# Altium schematic sheet MainBoard.SchDoc
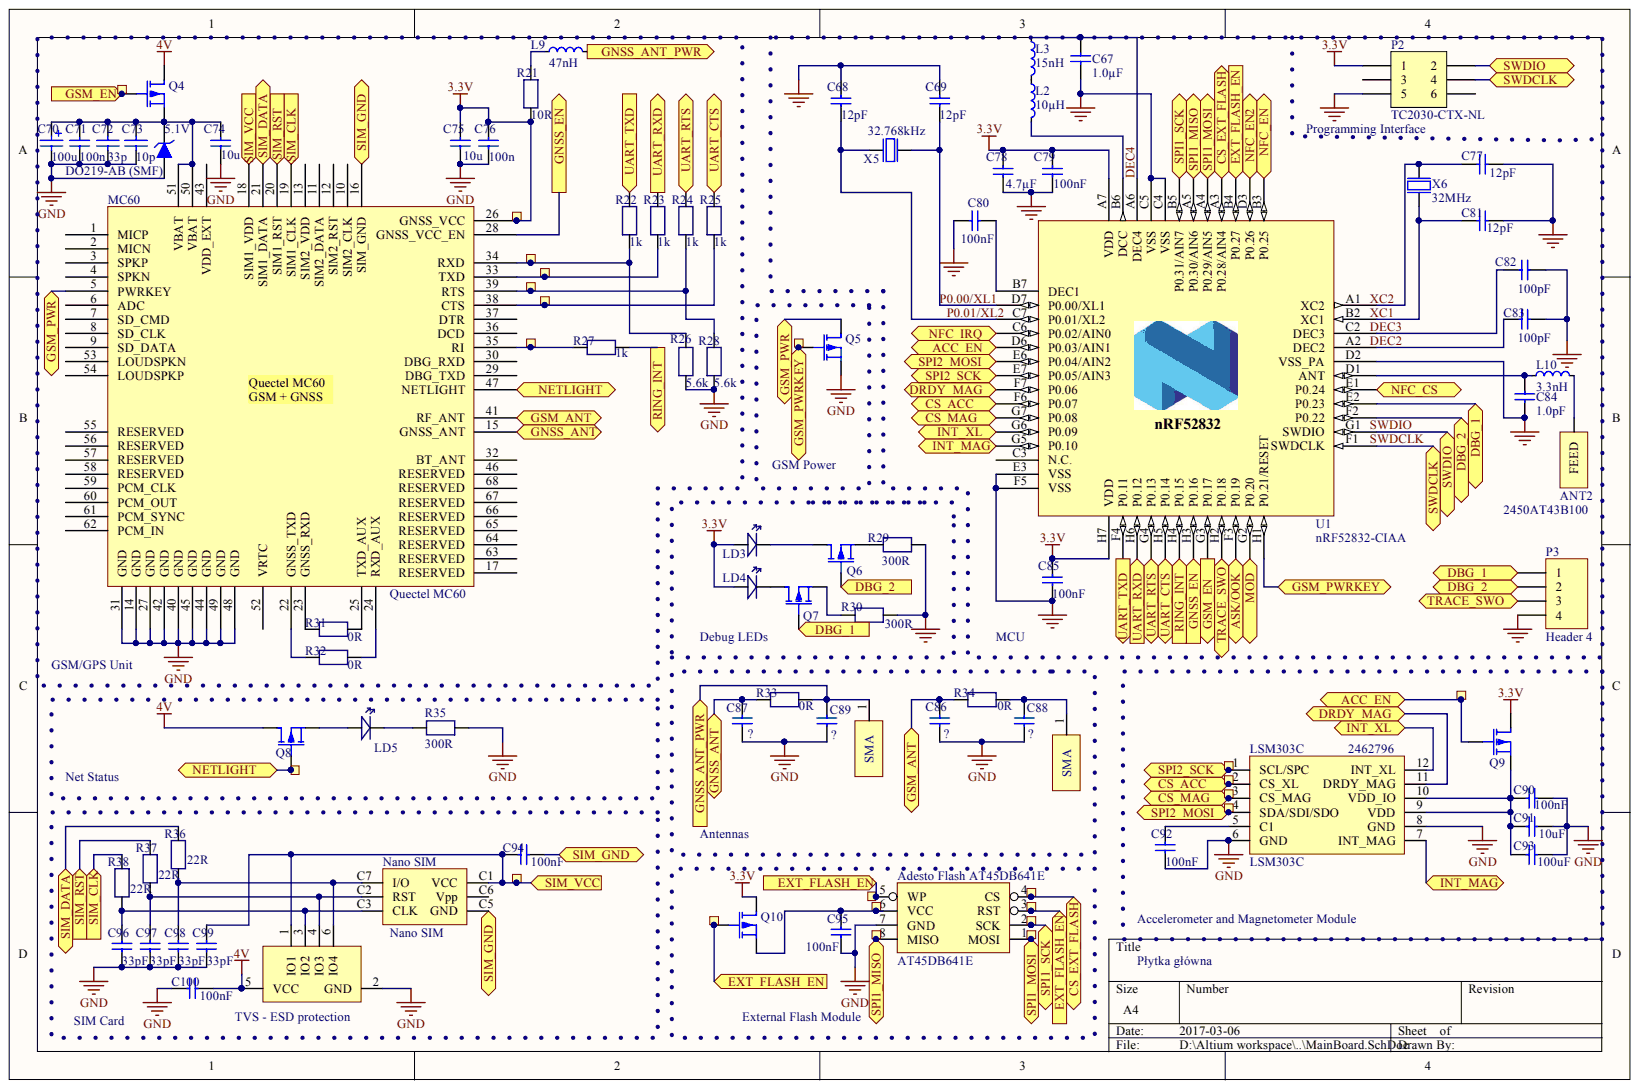
[diagram p0: MainBoard.SchDoc]

## Parts
5  Ø1.0mm,SMD  [TP_SMD_Ø1.0mm]  (value not drawn)  (no BOM)
5.1V  DO219-AB (SMF)  [DO219-AB]
6  Ø1.0mm,SMD  [TP_SMD_Ø1.0mm]  (value not drawn)  (no BOM)
7  Ø1.0mm,SMD  [TP_SMD_Ø1.0mm]  (value not drawn)  (no BOM)
8  Ø1.0mm,SMD  [TP_SMD_Ø1.0mm]  (value not drawn)  (no BOM)
9  Ø1.0mm,SMD  [TP_SMD_Ø1.0mm]  (value not drawn)  (no BOM)
10  Ø1.0mm,SMD  [TP_SMD_Ø1.0mm]  (value not drawn)  (no BOM)
11  Ø1.0mm,SMD  [TP_SMD_Ø1.0mm]  (value not drawn)  (no BOM)
12  Ø1.0mm,SMD  [TP_SMD_Ø1.0mm]  (value not drawn)  (no BOM)
13  Ø1.0mm,SMD  [TP_SMD_Ø1.0mm]  (value not drawn)  (no BOM)
14  Ø1.0mm,SMD  [TP_SMD_Ø1.0mm]  (value not drawn)  (no BOM)
15  Ø1.0mm,SMD  [TP_SMD_Ø1.0mm]  (value not drawn)  (no BOM)
16  Ø1.0mm,SMD  [TP_SMD_Ø1.0mm]  (value not drawn)  (no BOM)
17  Ø1.0mm,SMD  [TP_SMD_Ø1.0mm]  (value not drawn)  (no BOM)
18  Ø1.0mm,SMD  [TP_SMD_Ø1.0mm]  (value not drawn)  (no BOM)
19  Ø1.0mm,SMD  [TP_SMD_Ø1.0mm]  (value not drawn)  (no BOM)
20  Ø1.0mm,SMD  [TP_SMD_Ø1.0mm]  (value not drawn)  (no BOM)
21  Ø1.0mm,SMD  [TP_SMD_Ø1.0mm]  (value not drawn)  (no BOM)
22  Ø1.0mm,SMD  [TP_SMD_Ø1.0mm]  (value not drawn)  (no BOM)
23  Ø1.0mm,SMD  [TP_SMD_Ø1.0mm]  (value not drawn)  (no BOM)
24  Ø1.0mm,SMD  [TP_SMD_Ø1.0mm]  (value not drawn)  (no BOM)
25  Ø1.0mm,SMD  [TP_SMD_Ø1.0mm]  (value not drawn)  (no BOM)
26  Ø1.0mm,SMD  [TP_SMD_Ø1.0mm]  (value not drawn)  (no BOM)
27  Ø1.0mm,SMD  [TP_SMD_Ø1.0mm]  (value not drawn)  (no BOM)
Adesto_Flash_AT45DB641E  AT45DB641E  [UDFN 5x6mm 8pin]
ANT2  2450AT43B100  [2450AT43B100]
C67  1.0µF  [CAPC1005X04L]
C68  12pF  [CAPC0603X03L]
C69  12pF  [CAPC0603X03L]
C70  value 100u  [B]
C71  100n  [CAPC1005X04L]
C72  value 33p  [1608[0603]]
C73  value 10p  [1608[0603]]
C74  10u  [CAPC1608X06L]
C75  10u  [CAPC1608X06L]
C76  100n  [CAPC1005X04L]
C77  12pF  [CAPC0603X03L]
C78  4.7µF  [CAPC1608X06L]
C79  100nF  [CAPC1005X04L]
C80  100nF  [CAPC1005X04L]
C81  12pF  [CAPC0603X03L]
C82  100pF  [CAPC0603X03L]
C83  100pF  [CAPC0603X03L]
C84  1.0pF  [CAPC0603X03L]
C85  100nF  [CAPC1005X04L]
C86  ?  [CAPC1005X04L]
C87  ?  [CAPC1005X04L]
C88  ?  [CAPC1005X04L]
C89  ?  [CAPC1005X04L]
C90  100nF  [CAPC1005X04L]
C91  10uF  [CAPC1005X04L]
C92  100nF  [CAPC1005X04L]
C93  100uF  [CAPC1005X04L]
C94  100nF  [CAPC1005X04L]
C95  100nF  [CAPC1005X04L]
C96  33pF  [CAPC1005X04L]
C97  33pF  [CAPC1005X04L]
C98  33pF  [CAPC1005X04L]
C99  33pF  [CAPC1005X04L]
C100  100nF  [CAPC1005X04L]
L2  10µH  [INDC1608X06L]
L3  15nH  [INDC1005X04L]
L9  47nH  [INDC1005X04L]
L10  3.3nH  [INDC0603X03L]
LD3  0603_LED  [LED_0603]  (value not drawn)
LD4  0603_LED  [LED_0603]  (value not drawn)
LD5  0603_LED  [LED_0603]  (value not drawn)
LSM303C  LSM303C  Supplier Part Number 1: 2462796  [LGA-12]
MC60  Quectel MC60  [Quactel MC60 Footprint]
Nano_SIM  Nano SIM  [NANO_Sim_Holder]
P2  TC2030-CTX-NL  [TC2030-IDC-NLFP]  (no BOM)
P3  Header 4  [HDR1X4]
Q4  RZE002P02TLTR-ND  [SOT-416]  (value not drawn)
Q5  RZE002P02TLTR-ND  [SOT-416]  (value not drawn)
Q6  RZE002P02TLTR-ND  [SOT-416]  (value not drawn)
Q7  RZE002P02TLTR-ND  [SOT-416]  (value not drawn)
Q8  RZE002P02TLTR-ND  [SOT-416]  (value not drawn)
Q9  RZE002P02TLTR-ND  [SOT-416]  (value not drawn)
Q10  RZE002P02TLTR-ND  [SOT-416]  (value not drawn)
R21  value 10R  [RES_0402]  (hidden comment: RES_0402_1k)
R22  value 1k  [RES_0402]  (hidden comment: RES_0402_1k)
R23  value 1k  [RES_0402]  (hidden comment: RES_0402_1k)
R24  value 1k  [RES_0402]  (hidden comment: RES_0402_1k)
R25  value 1k  [RES_0402]  (hidden comment: RES_0402_1k)
R26  value 5.6k  [RES_0402]  (hidden comment: RES_0402_1k)
R27  value 1k  [RES_0402]  (hidden comment: RES_0402_1k)
R28  value 5.6k  [RES_0402]  (hidden comment: RES_0402_1k)
R29  value 300R  [RES_0402]  (hidden comment: RES_0402_1k)
R30  value 300R  [RES_0402]  (hidden comment: RES_0402_1k)
R31  value 0R  [RES_0402]  (hidden comment: RES_0402_1k)
R32  value 0R  [RES_0402]  (hidden comment: RES_0402_1k)
R33  value 0R  [RES_0402]  (hidden comment: RES_0402_1k)
R34  value 0R  [RES_0402]  (hidden comment: RES_0402_1k)
R35  value 300R  [RES_0402]  (hidden comment: RES_0402_1k)
R36  value 22R  [RES_0402]  (hidden comment: RES_0402_1k)
R37  value 22R  [RES_0402]  (hidden comment: RES_0402_1k)
R38  value 22R  [RES_0402]  (hidden comment: RES_0402_1k)
SMA_connector  GSM_SMA  [SMA_Footprint]  (value not drawn)
SMA_connector  GNSS_SMA  [SMA_Footprint]  (value not drawn)
U1  nRF52832-CIAA  [BGA50C40P7X8_295X322X50]
X5  32.768kHz  [XTAL_2012]
X6  32MHz  [BT-XTAL_2016]
  TVS - ESD protection  [SO-G6/P.95]

## Ports
ACC_EN, ASK/OOK, CS_ACC, CS_EXT_FLASH, CS_MAG, DBG_1, DBG_2, DRDY_MAG, EXT_FLASH_EN, GNSS_ANT, GNSS_ANT_PWR, GNSS_EN, GSM_ANT, GSM_EN, GSM_PWR, GSM_PWRKEY, INT_MAG, INT_XL, MOD, NETLIGHT, NFC_CS, NFC_EN, NFC_EN2, NFC_IRQ, RING_INT, SIM_CLK, SIM_DATA, SIM_GND, SIM_RST, SIM_VCC, SPI1_MISO, SPI1_MOSI, SPI1_SCK, SPI2_MOSI, SPI2_SCK, SWDCLK, SWDIO, TRACE_SWO, UART_CTS, UART_RTS, UART_RXD, UART_TXD

## Nets
3.3V: 6.1 C75.1 C76.2 C78.1 C79.2 C85.2 LD3.1 LD4.1 MC60.26(GNSS_VCC) P2.1 Q9.3 Q10.3 R21.2 U1.A7(VDD) U1.H7(VDD)
4V: C100.2 Q4.3 Q8.3 .5(VCC)
GND: 5.1V.1 Adesto_Flash_AT45DB641E.7(GND) C67.1 C68.2 C69.2 C70.2 C71.1 C72.1 C73.1 C74.2 C75.2 C76.1 C77.1 C78.2 C79.1 C80.2 C81.1 C82.2 C83.1 C84.1 C85.1 C86.2 C87.2 C88.2 C89.2 C90.2 C91.1 C92.1 C93.1 C95.1 C96.2 C97.2 C98.1 C99.2 C100.1 LSM303C.6(GND) LSM303C.8(GND) MC60.14(GND) MC60.27(GND) MC60.31(GND) MC60.40(GND) MC60.42(GND) MC60.44(GND) MC60.45(GND) MC60.48(GND) MC60.49(GND) P2.5 P3.4 Q5.2 R26.1 R28.1 R29.1 R30.1 R35.1 U1.C4(VSS) U1.C5(VSS) U1.D2(VSS_PA) U1.E3(VSS) U1.F5(VSS) X6.2 X6.4 .2(GND)
Net_3: C96.1 Nano_SIM.C3(CLK) R38.2 .3(IO2)
Net_4: C97.1 Nano_SIM.C2(RST) R37.2 .4(IO3)
Net_6: C98.2 Nano_SIM.C7(I/O) R36.2 .6(IO4)
ACC_EN: 13.1 Q9.1 U1.D6(P0.03/AIN1) ; port ACC_EN
ASK/OOK: U1.F3(P0.19) ; port ASK/OOK
CS_ACC: 16.1 LSM303C.2(CS_XL) U1.F6(P0.07) ; port CS_ACC
CS_EXT_FLASH: 20.1 Adesto_Flash_AT45DB641E.4(CS) U1.A3(P0.28/AIN4) ; port CS_EXT_FLASH
CS_MAG: 17.1 LSM303C.3(CS_MAG) U1.G7(P0.08) ; port CS_MAG
DBG_1: P3.1 Q7.1 U1.E2(P0.23) ; port DBG_1
DBG_2: P3.2 Q6.1 U1.F2(P0.22) ; port DBG_2
DEC4: C67.2 L3.1 U1.A6(DEC4)
DRDY_MAG: LSM303C.11(DRDY_MAG) U1.F7(P0.06) ; port DRDY_MAG
EXT_FLASH_EN: 21.1 23.1 24.1 Adesto_Flash_AT45DB641E.3(RST) Adesto_Flash_AT45DB641E.5(WP) Q10.1 U1.B4(P0.27) ; port EXT_FLASH_EN
GNSS_ANT: C87.1 MC60.15(GNSS_ANT) R33.1 ; port GNSS_ANT
GNSS_ANT_PWR: C89.1 L9.2 R33.2 SMA_connector.1 ; port GNSS_ANT_PWR
GNSS_EN: MC60.28(GNSS_VCC_EN) U1.H3(P0.16) ; port GNSS_EN
GSM_ANT: C86.1 MC60.41(RF_ANT) R34.2 ; port GSM_ANT
GSM_EN: 5.1 Q4.1 U1.G3(P0.17) ; port GSM_EN
GSM_PWR: MC60.5(PWRKEY) Q5.3 ; port GSM_PWR
GSM_PWRKEY: 12.1 Q5.1 U1.H1(P0.21/RESET) ; port GSM_PWRKEY
INT_MAG: LSM303C.7(INT_MAG) U1.G5(P0.10) ; port INT_MAG
INT_XL: LSM303C.12(INT_XL) U1.G6(P0.09) ; port INT_XL
MOD: U1.G2(P0.20) ; port MOD
Net_1: 19.1 C94.1 C99.1 MC60.18(SIM1_VDD) Nano_SIM.C1(VCC) .1(IO1) ; port SIM_VCC
NETLIGHT: 15.1 MC60.47(NETLIGHT) Q8.1 ; port NETLIGHT
NFC_CS: U1.E1(P0.24) ; port NFC_CS
NFC_EN: U1.B3(P0.25) ; port NFC_EN
NFC_EN2: U1.D3(P0.26) ; port NFC_EN2
NFC_IRQ: U1.C6(P0.02/AIN0) ; port NFC_IRQ
P0.00/XL1: C69.1 U1.D7(P0.00/XL1) X5.2
P0.01/XL2: C68.1 U1.C7(P0.01/XL2) X5.1
RING_INT: R27.1 U1.H4(P0.15) ; port RING_INT
SIM_CLK: MC60.19(SIM1_CLK) R38.1 ; port SIM_CLK
SIM_DATA: MC60.21(SIM1_DATA) R36.1 ; port SIM_DATA
SIM_GND: C94.2 MC60.16(SIM_GND) Nano_SIM.C5(GND) ; port SIM_GND
SIM_RST: MC60.20(SIM1_RST) R37.1 ; port SIM_RST
SPI1_MISO: 26.1 Adesto_Flash_AT45DB641E.8(MISO) U1.A5(P0.30/AIN6) ; port SPI1_MISO
SPI1_MOSI: 27.1 Adesto_Flash_AT45DB641E.1(MOSI) U1.A4(P0.29/AIN5) ; port SPI1_MOSI
SPI1_SCK: 25.1 Adesto_Flash_AT45DB641E.2(SCK) U1.B5(P0.31/AIN7) ; port SPI1_SCK
SPI2_MOSI: 18.1 LSM303C.4(SDA/SDI/SDO) U1.E6(P0.04/AIN2) ; port SPI2_MOSI
SPI2_SCK: 14.1 LSM303C.1(SCL/SPC) U1.E7(P0.05/AIN3) ; port SPI2_SCK
SWDCLK: P2.4 U1.F1(SWDCLK) ; port SWDCLK
SWDIO: P2.2 U1.G1(SWDIO) ; port SWDIO
TRACE_SWO: P3.3 U1.H2(P0.18) ; port TRACE_SWO
UART_CTS: R25.2 U1.H5(P0.14) ; port UART_CTS
UART_RTS: R24.2 U1.G4(P0.13) ; port UART_RTS
UART_RXD: R23.2 U1.H6(P0.12) ; port UART_RXD
UART_TXD: R22.2 U1.F4(P0.11) ; port UART_TXD
Net5.1V_2: 5.1V.2 C70.1 C71.2 C72.2 C73.2 C74.1 MC60.50(VBAT) MC60.51(VBAT) Q4.2
Net7_1: 7.1 MC60.34(RXD) R22.1 R26.2
Net8_1: 8.1 MC60.33(TXD) R23.1
Net9_1: 9.1 MC60.39(RTS) R24.1 R28.2
Net10_1: 10.1 MC60.38(CTS) R25.1
Net11_1: 11.1 MC60.35(RI) R27.2
Net22_1: 22.1 Adesto_Flash_AT45DB641E.6(VCC) C95.2 Q10.2
NetANT2_1: ANT2.1 L10.2
NetC77_2: C77.2 U1.A1(XC2) X6.3
NetC80_1: C80.1 U1.B7(DEC1)
NetC81_2: C81.2 U1.B2(XC1) X6.1
NetC82_1: C82.1 U1.C2(DEC3)
NetC83_2: C83.2 U1.A2(DEC2)
NetC84_2: C84.2 L10.1 U1.D1(ANT)
NetC88_1: C88.1 R34.1 SMA_connector.1
NetC90_1: C90.1 C91.2 C93.2 LSM303C.9(VDD) LSM303C.10(VDD_IO) Q9.2
NetC92_2: C92.2 LSM303C.5(C1)
NetL2_1: L2.1 L3.2
NetL2_2: L2.2 U1.B6(DCC)
NetL9_1: L9.1 R21.1
NetLD3_2: LD3.2 Q6.3
NetLD4_2: LD4.2 Q7.3
NetLD5_1: LD5.1 Q8.2
NetLD5_2: LD5.2 R35.2
NetMC60_22: MC60.22(GNSS_TXD) R32.1
NetMC60_23: MC60.23(GNSS_RXD) R31.1
NetMC60_24: MC60.24(RXD_AUX) R32.2
NetMC60_25: MC60.25(TXD_AUX) R31.2
NetP2_3: P2.3
NetP2_6: P2.6
NetQ6_2: Q6.2 R29.2
NetQ7_2: Q7.2 R30.2
NetU1_C3: U1.C3(N.C.)
DEC2:
DEC3:
SWDCLK:
SWDIO:
XC1:
XC2:

Not connected: MC60 1(MICP) 2(MICN) 3(SPKP) 4(SPKN) 6(ADC) 7(SD_CMD) 8(SD_CLK) 9(SD_DATA) 10(SIM2_CLK) 11(SIM2_DATA) 12(SIM2_RST) 13(SIM2_VDD) 17(RESERVED) 29(DBG_TXD) 30(DBG_RXD) 32(BT_ANT) 36(DCD) 37(DTR) 43(VDD_EXT) 46(RESERVED) 52(VRTC) 53(LOUDSPKN) 54(LOUDSPKP) 55(RESERVED) 56(RESERVED) 57(RESERVED) 58(RESERVED) 59(PCM_CLK) 60(PCM_OUT) 61(PCM_SYNC) 62(PCM_IN) 63(RESERVED) 64(RESERVED) 65(RESERVED) 66(RESERVED) 67(RESERVED) 68(RESERVED); Nano_SIM C6(Vpp)

## Library data of the parts
5-27 = TESTPAD: 1.0mm circular SMD testpad; Manufacturer: Nordic Semiconductor; Artikkelnr Noca: N.A.; LatestRevisionDate: 2013-06-11; Library Name: pca20006.IntLib; Part Number: TP_SMD_Ø1.0MM; Pin Count: 1; Published: 2013-06-11; Value: Ø1.0mm,SMD; Publisher: Nordic Semiconductor
5.1V = Zener Diode: Component Kind: Standard; Library Name: Zener_Diode.SchLib; Supplier 1: Farnell; Supplier Part Number 1: 1703019
C67 = C0402-1µ0: Capacitor, X5R, ±5%; Artikkelnr Noca: 20039235; Artikkelnr Simpro: N.A.; Database Table Name: Capacitors$; Note: 6.3V; Component Kind: Standard; Footprint Doc: 0402; LatestRevisionDate: 2009-11-23; Library Name: Nordic Passive DB.DbLib; Part Number: C0402-1µ0; Pin Count: 2; Published: 2009-05-08; Value: 1.0µF; Publisher: Nordic Semiconductor
C68 C69 C77 C81 = C0201-12p: Capacitor, NP0, ±2%; Artikkelnr Noca: N.A.; Database Table Name: Capacitors$; Note: 50V; Artikkelnr Simpro: N.A.; Component Kind: Standard; Footprint Doc: 0201; LatestRevisionDate: 2014-11-13; Library Name: Nordic Passive DB.DbLib; Part Number: C0201-12p; Pin Count: 2; Published: 2014-11-13; Value: 12pF; Publisher: Nordic Semiconductor
C70 = CAP_B_100u: Solid Tantalum Capacitor; Component Kind: Standard; Signal Integrity: T491A; Simulation: CAP; Supplier 1: Farnell; Supplier Part Number 1: 1658510; Library Name: ONEMETER.SchLib
C71 C76 C79 C80 C85-C100 = CAP_0402: Capacitor, NP0, ±2%; Part Number: C0402-12p; Artikkelnr Noca: 20029159; Artikkelnr Simpro: N.A.; Note: 50V; Value: 12pF; Component Kind: Standard; Footprint Doc: 0402; LatestRevisionDate: 2011-05-11; Library Name: pca10031.IntLib; Pin Count: 2; Published: 2009-05-08; Publisher: Nordic Semiconductor
  C91: Supplier 1: Farnell; Supplier Part Number 1: 2218854
C72 C73 = Cap Semi: Capacitor (Semiconductor SIM Model); Component Kind: Standard; LatestRevisionDate: 29-May-2009; LatestRevisionNote: IPC-7351 Footprint Added.; Library Name: Miscellaneous Devices.IntLib; PackageDescription: Chip Capacitor; PackageReference: C1206; Published: 8-Jun-2000; Signal Integrity: Cap Semi; Simulation: CAP; Supplier 1: Farnell; Publisher: Altium Limited
  C72: Supplier Part Number 1: 1907290
  C73: Supplier Part Number 1: 2309035
C74 C75 = C0603-4µ7: Capacitor, X5R, ±10%; Artikkelnr Noca: 20069060; Artikkelnr Simpro: N.A.; Database Table Name: Capacitors$; Note: 6.3V; Value: 4.7µF; Component Kind: Standard; Footprint Doc: 0603; LatestRevisionDate: 2009-11-23; Library Name: Nordic Passive DB.DbLib; Part Number: C0603-4µ7; Pin Count: 2; Published: 2009-05-08; Publisher: Nordic Semiconductor
C78 = C0603-4µ7: Capacitor, X5R, ±10%; Artikkelnr Noca: 20069060; Artikkelnr Simpro: N.A.; Database Table Name: Capacitors$; Note: 6.3V; Component Kind: Standard; Footprint Doc: 0603; LatestRevisionDate: 2009-11-23; Library Name: Nordic Passive DB.DbLib; Part Number: C0603-4µ7; Pin Count: 2; Published: 2009-05-08; Value: 4.7µF; Publisher: Nordic Semiconductor
C82 C83 = C0201-100p: Capacitor, NP0, ±5%; Artikkelnr Noca: N.A.; Database Table Name: Capacitors$; Note: Chip Cap 1%; Artikkelnr Simpro: N.A.; Component Kind: Standard; Footprint Doc: 0201; LatestRevisionDate: 2014-11-13; Library Name: Nordic Passive DB.DbLib; Part Number: C0201-100p; Pin Count: 2; Published: 2014-11-13; Value: 100pF; Publisher: Nordic Semiconductor
C84 = C0201-1.0p: Capacitor, NP0, ±5%; Artikkelnr Noca: N.A.; Database Table Name: Capacitors$; Note: Chip Cap 1%; Artikkelnr Simpro: N.A.; Component Kind: Standard; Footprint Doc: 0201; LatestRevisionDate: 2014-11-13; Library Name: Nordic Passive DB.DbLib; Part Number: C0201-1.0p; Pin Count: 2; Published: 2014-11-13; Value: 1.0pF; Publisher: Nordic Semiconductor
L2 = L0603-10µ: Chip inductor, IDC,min = 50 mA, ±20%; 2nd source part number: 178-6105; Manufacturer: Taiyo Yuden; Manufacturer Part Number: LBMF1608T100K; Artikkelnr Noca: 33029314; Artikkelnr Simpro: N.A.; Database Table Name: Inductors$; Note: LBMF1608T100K 10µH 80mA 0603; 2nd source Supplier: Farnell; Component Kind: Standard; Datasheet: http://www.yuden.co.jp/ut/product/pdf/elb2_e.pdf; Footprint Doc: 0603; LatestRevisionDate: 2012-09-19; Library Name: Nordic Passive DB.DbLib; Part Number: L0603-10µ; Pin Count: 2; Published: 2010-02-24; Supplier: Digi-Key; Supplier part number: 587-1714-1-ND; Value: 10µH; Publisher: Nordic Semiconductor
L3 = L0402-15n: High frequency chip inductor ±10%; 2nd source part number: 658-1328; Manufacturer: Taiyo Yuden; Manufacturer Part Number: HK100515NJ-T; Artikkelnr Noca: 33029323; Artikkelnr Simpro: N.A.; Database Table Name: Inductors$; 2nd source Supplier: Farnell; Component Kind: Standard; Datasheet: http://www.yuden.co.jp/productdata/catalog/en/mlci04_e.pdf; Footprint Doc: 0402; LatestRevisionDate: 2012-09-19; Library Name: Nordic Passive DB.DbLib; Note: High frequency chip inductor ±10%; Part Number: L0402-15n; Pin Count: 2; Published: 2010-07-12; Supplier: Digi-Key; Supplier part number: 587-1521-1-ND; Value: 15nH; Publisher: Nordic Semiconductor
L9 = L0402-15n: High frequency chip inductor ±10%; Database Table Name: Inductors$; Component Kind: Standard; Library Name: Nordic Passive DB.DbLib; Supplier 1: Farnell; Supplier Part Number 1: 2285742
L10 = L0201-3n3: High frequency chip inductor ±5%; Artikkelnr Noca: N.A.; Database Table Name: Inductors$; Artikkelnr Simpro: N.A.; Component Kind: Standard; Footprint Doc: 0201; LatestRevisionDate: 2013-04-10; Library Name: Nordic Passive DB.DbLib; Note: High frequency chip inductor ±5%; Part Number: L0201-3n3; Pin Count: 2; Published: 2013-04-10; Value: 3.3nH; Publisher: Nordic Semiconductor
LD3-LD5 = LED_0603_Blue: Component Kind: Standard; Supplier 1: Digi-Key; Supplier 2: TME; Supplier 3: TME; Supplier 4: TME; Supplier 5: TME; Supplier Part Number 1: 160-1647-2-ND; Supplier Part Number 2: LL-S192PBC-B4-1B; Supplier Part Number 3: LL-S194PBC-B4-1B; Supplier Part Number 4: OF-SMD1608B; Supplier Part Number 5: OSB50603C1D; Library Name: ONEMETER.SchLib
P2 = TC2030-CTX-NL: CBL PLUG-OF-NAILS 6-PIN; Manufacturer: Tag-Connect LLC; Artikkelnr Noca: N.A.; Database Table Name: Connectors$; Datasheet: http://www.tag-connect.com/Materials/TC2030-IDC-NL.pdf; LatestRevisionDate: 2013-08-08; Library Name: Nordic Passive DB.DbLib; Manufacturer Part Number: TC2030-CTX-NL; Part Number: TC2030-CTX-NL; Pin Count: 6; Published: 2013-08-08; Supplier: Tag-Connect; Supplier part number: TC2030-CTX-NL; Value: TC2030-CTX-NL; Publisher: Nordic Semiconductor
P3 = Header 4: Header, 4-Pin; Component Kind: Standard; LatestRevisionDate: 17-Jul-2002; LatestRevisionNote: Re-released for DXP Platform.; Library Name: Miscellaneous Connectors.IntLib; Signal Integrity: Connector; Publisher: Altium Limited
SMA_connector SMA_connector = Component_1: Component Kind: Standard; Library Name: SMA_connector.SchLib; Supplier 1: Farnell; Supplier Part Number 1: 4194615
U1 = nRF52832-CIAA: Multi-protocol Bluetooth Low Energy, ANT and 2.4GHz proprietary system-on-chip; Manufacturer: Nordic Semiconductor; Artikkelnr Noca: N.A.; Database Table Name: nRF Devices$; Artikkelnr Simpro: N.A.; Component Kind: Standard; Footprint Doc: WLCSP_C50; LatestRevisionDate: 2016-03-11; Library Name: Nordic Active DB.DbLib; Manufacturer Part Number: nRF52832-CIAA; Part Number: nRF52832-CIAA; Part number IPS: N.A.; Pin Count: 54; Published: 2016-03-11; Supplier: Nordic Semiconductor; Value: nRF52832-CIAA; Publisher: Nordic Semiconductor
X5 = XTAL_SMD2012_32.768kHz_9pF_Seiko: XTAL SMD 2012, 32.768kHz, Cl=9pF, Total Tol: ±50ppm; Manufacturer: Seiko; Manufacturer Part Number: SC20S-9.0PF20PPM; Artikkelnr Noca: N.A.; Database Table Name: Crystal_Osc$; Note: XTAL SMD 32.768kHz, 2012; Artikkelnr Simpro: N.A.; Component Kind: Standard; Datasheet: http://www.sii.co.jp/en/quartz/files/2013/03/file_PRODUCT_MASTER_50811_GRAPHIC03.pdf; Footprint Doc: XTAL_2012; LatestRevisionDate: 2015-10-15; Library Name: Nordic Discrete DB.DbLib; Part Number: XTAL_SMD2012_32.768kHz_9pF_Seiko; Pin Count: 2; Published: 2015-10-15; Value: 32.768kHz; Publisher: Nordic Semiconductor
X6 = XTAL_SMD2016_32MHz_8pF_Epson: XTAL SMD 2016, 32MHz, Cl=8pF, Total Tol: ±40ppm; Manufacturer: Epson Toyocom; Manufacturer Part Number: Q22FA12800025; Artikkelnr Noca: N.A.; Database Table Name: Crystal_Osc$; Note: XTAL SMD 32.000MHz; Artikkelnr Simpro: N.A.; Component Kind: Standard; Datasheet: http://www.epsondevice.com/docs/qd/en/DownloadServlet?id=ID000449; Footprint Doc: XTAL_2016; LatestRevisionDate: 2014-11-27; Library Name: Nordic Discrete DB.DbLib; Part Number: XTAL_SMD2016_32MHz_8pF_Epson; Pin Count: 4; Published: 2012-03-09; Supplier: Farnell; Supplier part number: 171-2806; Value: 32MHz; Publisher: Nordic Semiconductor
 = TPD4E001-DBV: Component Kind: Standard; Library Name: TPD4E001.SchLib
Adesto_Flash_AT45DB641E = AT45DB641E: Component Kind: Standard; Library Name: Adesto_Flash.SchLib
ANT2 = 2450AT43B100: Component Kind: Standard; Supplier 1: Mouser; Supplier 2: Farnell; Supplier 3: Farnell; Supplier 4: Digi-Key; Supplier 5: Digi-Key; Supplier 6: Digi-Key; Supplier Part Number 1: 609-2450AT43B100E; Supplier Part Number 2: 2148524; Supplier Part Number 3: 2148524RL; Supplier Part Number 4: 712-1010-2-ND; Supplier Part Number 5: 712-1010-1-ND; Supplier Part Number 6: 712-1010-6-ND; Library Name: ONEMETER.SchLib
LSM303C = LSM303C: Component Kind: Standard; Supplier 1: Farnell; Library Name: Accelerometer_Mag_Gyro.SchLib
MC60 = Quectel MC60: Component Kind: Standard; Library Name: QUECTEL_MC60_GSM_GNSS.SchLib
Nano_SIM = Nano SIM: Component Kind: Standard; Library Name: SIM_Card_Holder.SchLib
Q4-Q10 = RZE002P02TLTR-ND: Component Kind: Standard; Supplier 1: Digi-Key; Supplier 2: Digi-Key; Supplier 3: Digi-Key; Supplier Part Number 1: RZE002P02TLTR-ND; Supplier Part Number 2: RZE002P02TLCT-ND; Supplier Part Number 3: RZE002P02TLDKR-ND; Value: RZE002P02TL; Library Name: ONEMETER.SchLib
R21-R38 = RES_0402_1k: Component Kind: Standard; Supplier 1: Digi-Key; Supplier 2: Farnell; Supplier 3: Mouser; Supplier Part Number 1: 311-1.0KJRTR-ND; Supplier Part Number 2: 1358043; Supplier Part Number 3: 603-RC0402FR-071KL; Library Name: ONEMETER.SchLib
